# Altium schematic sheet ICWSHLP2_Adaptor_2x2_Power_PreReg.SchDoc(ICWSHLP2_Adaptor_2x2_Power_PreReg)
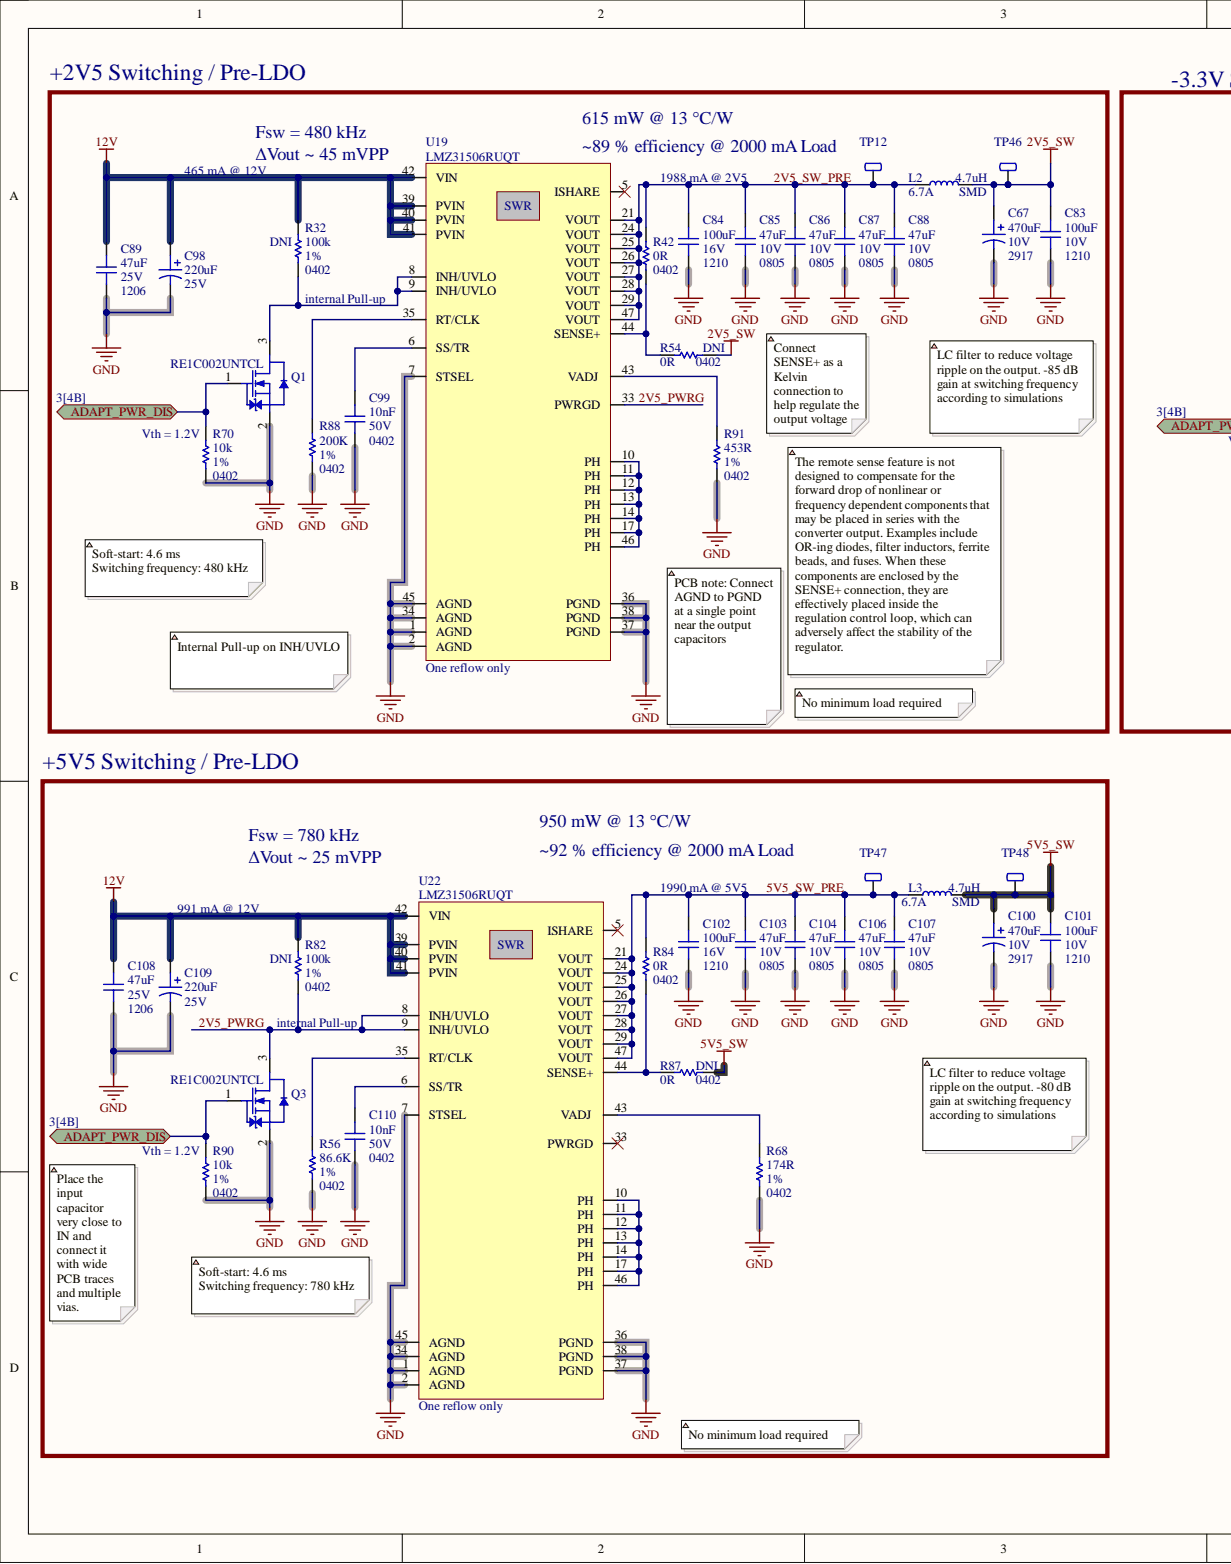
[diagram p0: ICWSHLP2_Adaptor_2x2_Power_PreReg.SchDoc, left]
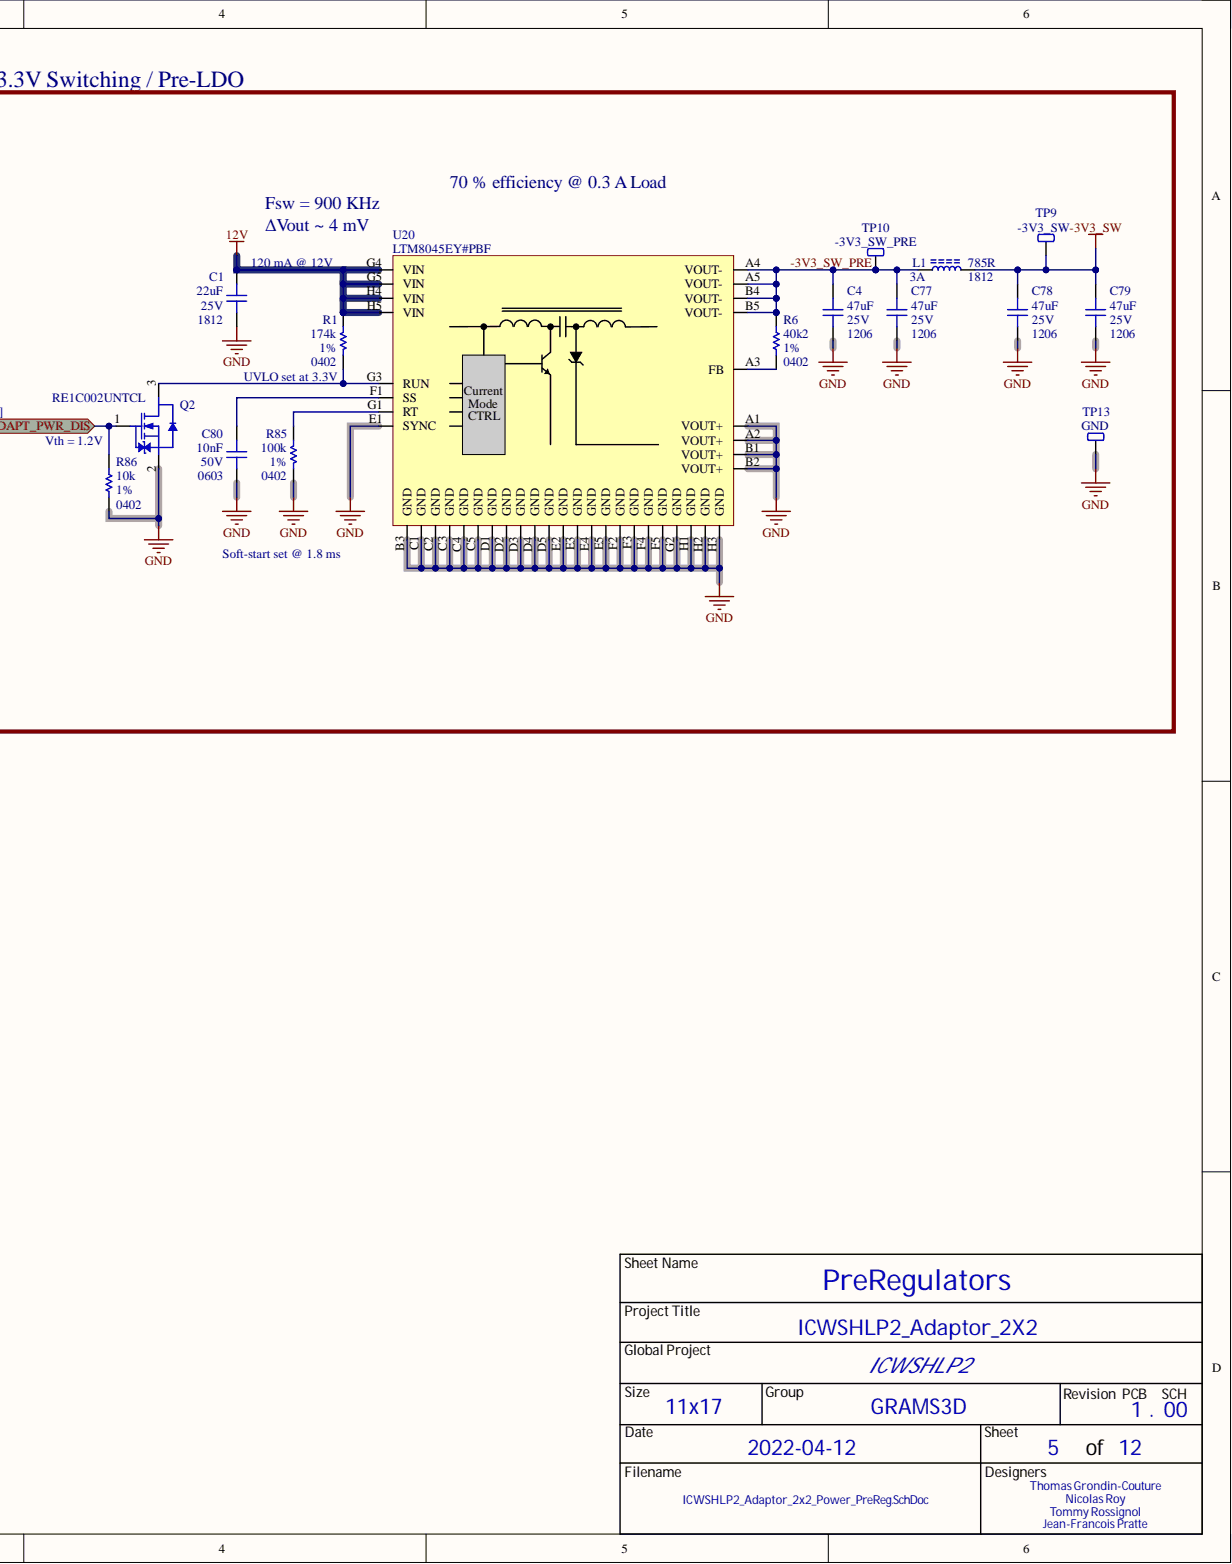
[diagram p1: ICWSHLP2_Adaptor_2x2_Power_PreReg.SchDoc, right]

## Parts
C1  value 22uF  Package: 1812  Voltage Rating: 25V  [CAP_CER_1812]  (hidden comment: C4532X7R1E226M250KC)
C4  value 47uF  Package: 1206  Voltage Rating: 25V  [CAP_CER_1206]  (hidden comment: C3216X5R1E476M160AC)
C67  value 470uF  Assembly Type: SMD  Package: 2917  Voltage Rating: 10V  [CAP_TAN_TR3E477M010C0200]  (hidden comment: TR3E477M010C0200)
C77  value 47uF  Package: 1206  Voltage Rating: 25V  [CAP_CER_1206]  (hidden comment: C3216X5R1E476M160AC)
C78  value 47uF  Package: 1206  Voltage Rating: 25V  [CAP_CER_1206]  (hidden comment: C3216X5R1E476M160AC)
C79  value 47uF  Package: 1206  Voltage Rating: 25V  [CAP_CER_1206]  (hidden comment: C3216X5R1E476M160AC)
C80  value 10nF  Package: 0603  Voltage Rating: 50V  [CAP_CER_0603]  (hidden comment: GRM1885C1H103JA01D)
C83  value 100uF  Package: 1210  Voltage Rating: 10V  [CAP_CER_1210]  (hidden comment: CL32A107MPVNNNE)
C84  value 100uF  Package: 1210  Voltage Rating: 16V  [CAP_CER_1210]  (hidden comment: C1210C107M4PAC7800)
C85  value 47uF  Package: 0805  Voltage Rating: 10V  [CAP_CER_0805]  (hidden comment: GRM21BR61A476ME15L)
C86  value 47uF  Package: 0805  Voltage Rating: 10V  [CAP_CER_0805]  (hidden comment: GRM21BR61A476ME15L)
C87  value 47uF  Package: 0805  Voltage Rating: 10V  [CAP_CER_0805]  (hidden comment: GRM21BR61A476ME15L)
C88  value 47uF  Package: 0805  Voltage Rating: 10V  [CAP_CER_0805]  (hidden comment: GRM21BR61A476ME15L)
C89  value 47uF  Package: 1206  Voltage Rating: 25V  [CAP_CER_1206]  (hidden comment: C3216X5R1E476M160AC)
C98  value 220uF  Voltage Rating: 25V  [CAP_POLY_870025575007]  (hidden comment: 870025575007)
C99  value 10nF  Package: 0402  Voltage Rating: 50V  [CAP_CER_0402]  (hidden comment: C0402C103M5RACTU)
C100  value 470uF  Assembly Type: SMD  Package: 2917  Voltage Rating: 10V  [CAP_TAN_TR3E477M010C0200]  (hidden comment: TR3E477M010C0200)
C101  value 100uF  Package: 1210  Voltage Rating: 10V  [CAP_CER_1210]  (hidden comment: CL32A107MPVNNNE)
C102  value 100uF  Package: 1210  Voltage Rating: 16V  [CAP_CER_1210]  (hidden comment: C1210C107M4PAC7800)
C103  value 47uF  Package: 0805  Voltage Rating: 10V  [CAP_CER_0805]  (hidden comment: GRM21BR61A476ME15L)
C104  value 47uF  Package: 0805  Voltage Rating: 10V  [CAP_CER_0805]  (hidden comment: GRM21BR61A476ME15L)
C106  value 47uF  Package: 0805  Voltage Rating: 10V  [CAP_CER_0805]  (hidden comment: GRM21BR61A476ME15L)
C107  value 47uF  Package: 0805  Voltage Rating: 10V  [CAP_CER_0805]  (hidden comment: GRM21BR61A476ME15L)
C108  value 47uF  Package: 1206  Voltage Rating: 25V  [CAP_CER_1206]  (hidden comment: C3216X5R1E476M160AC)
C109  value 220uF  Voltage Rating: 25V  [CAP_POLY_870025575007]  (hidden comment: 870025575007)
C110  value 10nF  Package: 0402  Voltage Rating: 50V  [CAP_CER_0402]  (hidden comment: C0402C103M5RACTU)
L1  value 785R  Current Rating: 3A  Package: 1812  [FER_1812]  (hidden comment: 742792515)
L2  value 4.7uH  Assembly Type: SMD  Current Rating: 6.7A  [IND_PG0871.472NLT]  (hidden comment: PG0871.472NLT)
L3  value 4.7uH  Assembly Type: SMD  Current Rating: 6.7A  [IND_PG0871.472NLT]  (hidden comment: PG0871.472NLT)
Q1  RE1C002UNTCL  [TRX_NMOS_RE1C002UNTCL]
Q2  RE1C002UNTCL  [TRX_NMOS_RE1C002UNTCL]
Q3  RE1C002UNTCL  [TRX_NMOS_RE1C002UNTCL]
R1  value 174k  Package: 0402  Tolerance: 1%  [RES_0402]  (hidden comment: RC0402FR-07174KL)
R6  value 40k2  Package: 0402  Tolerance: 1%  [RES_0402]  (hidden comment: RC0402FR-0740K2L)
R32  value 100k  Assembly Note: DNI  Package: 0402  Tolerance: 1%  [RES_0402]  (hidden comment: RC0402FR-07100KL)
R42  value 0R  Package: 0402  [RES_0402]  (hidden comment: RC0402JR-070RL)
R54  value 0R  Assembly Note: DNI  Package: 0402  [RES_0402]  (hidden comment: RC0402JR-070RL)
R56  value 86.6K  Package: 0402  Tolerance: 1%  [RES_0402]  (hidden comment: RC0402FR-0786K6L)
R68  value 174R  Package: 0402  Tolerance: 1%  [RES_0402]  (hidden comment: RC0402FR-07174RL)
R70  value 10k  Package: 0402  Tolerance: 1%  [RES_0402]  (hidden comment: RC0402FR-0710KP)
R82  value 100k  Assembly Note: DNI  Package: 0402  Tolerance: 1%  [RES_0402]  (hidden comment: RC0402FR-07100KL)
R84  value 0R  Package: 0402  [RES_0402]  (hidden comment: RC0402JR-070RL)
R85  value 100k  Package: 0402  Tolerance: 1%  [RES_0402]  (hidden comment: RC0402FR-07100KL)
R86  value 10k  Package: 0402  Tolerance: 1%  [RES_0402]  (hidden comment: RC0402FR-0710KP)
R87  value 0R  Assembly Note: DNI  Package: 0402  [RES_0402]  (hidden comment: RC0402JR-070RL)
R88  value 200K  Package: 0402  Tolerance: 1%  [RES_0402]  (hidden comment: RC0402FR-07200KL)
R90  value 10k  Package: 0402  Tolerance: 1%  [RES_0402]  (hidden comment: RC0402FR-0710KP)
R91  value 453R  Package: 0402  Tolerance: 1%  [RES_0402]  (hidden comment: ERJ-2RKF4530X)
TP9  -3V3_SW  [TPO_SMD_RCU-0C]
TP10  -3V3_SW_PRE  [TPO_SMD_RCU-0C]
TP12  2V5_SW_PRE  [TPO_SMD_RCU-0C]
TP13  GND  [TPO_SMD_RCU-0C]
TP46  2V5_SW  [TPO_SMD_RCU-0C]
TP47  5V5_SW_PRE  [TPO_SMD_RCU-0C]
TP48  5V5_SW  [TPO_SMD_RCU-0C]
U19  LMZ31506RUQT  Assembly Note: One reflow only  [REG_SWR_LMZ31506RUQT]
U20  LTM8045EY#PBF  [REG_SWR_LTM8045EY#PBF]
U22  LMZ31506RUQT  Assembly Note: One reflow only  [REG_SWR_LMZ31506RUQT]

## Ports
ADAPT_PWR_DIS

## Nets
2V5_SW: C67.1 C83.2 L2.1 R54.1 TP46.1
5V5_SW: C100.1 C101.2 L3.1 R87.1 TP48.1
12V: C1.2 C89.2 C98.1 C108.2 C109.1 R1.2 R32.2 R82.2 U19.39(PVIN) U19.40(PVIN) U19.41(PVIN) U19.42(VIN) U20.G4(VIN) U20.G5(VIN) U20.H4(VIN) U20.H5(VIN) U22.39(PVIN) U22.40(PVIN) U22.41(PVIN) U22.42(VIN)
-3V3_SW: C78.2 C79.2 L1.2 TP9.1
GND: C1.1 C4.1 C67.2 C77.1 C78.1 C79.1 C80.1 C83.1 C84.1 C85.1 C86.1 C87.1 C88.1 C89.1 C98.2 C99.1 C100.2 C101.1 C102.1 C103.1 C104.1 C106.1 C107.1 C108.1 C109.2 C110.1 Q1.2 Q2.2 Q3.2 R56.1 R68.1 R70.1 R85.1 R86.1 R88.1 R90.1 R91.1 TP13.1 U19.1(AGND) U19.2(AGND) U19.7(STSEL) U19.34(AGND) U19.36(PGND) U19.37(PGND) U19.38(PGND) U19.45(AGND) U20.A1(VOUT+) U20.A2(VOUT+) U20.B1(VOUT+) U20.B2(VOUT+) U20.B3(GND) U20.C1(GND) U20.C2(GND) U20.C3(GND) U20.C4(GND) U20.C5(GND) U20.D1(GND) U20.D2(GND) U20.D3(GND) U20.D4(GND) U20.D5(GND) U20.E1(SYNC) U20.E2(GND) U20.E3(GND) U20.E4(GND) U20.E5(GND) U20.F2(GND) U20.F3(GND) U20.F4(GND) U20.F5(GND) U20.G2(GND) U20.H1(GND) U20.H2(GND) U20.H3(GND) U22.1(AGND) U22.2(AGND) U22.7(STSEL) U22.34(AGND) U22.36(PGND) U22.37(PGND) U22.38(PGND) U22.45(AGND)
2V5_PWRG: Q3.3 R82.1 U19.33(PWRGD) U22.8(INH/UVLO) U22.9(INH/UVLO)
2V5_SW_PRE: C84.2 C85.2 C86.2 C87.2 C88.2 L2.2 R42.2 TP12.1 U19.21(VOUT) U19.24(VOUT) U19.25(VOUT) U19.26(VOUT) U19.27(VOUT) U19.28(VOUT) U19.29(VOUT) U19.47(VOUT)
5V5_SW_PRE: C102.2 C103.2 C104.2 C106.2 C107.2 L3.2 R84.2 TP47.1 U22.21(VOUT) U22.24(VOUT) U22.25(VOUT) U22.26(VOUT) U22.27(VOUT) U22.28(VOUT) U22.29(VOUT) U22.47(VOUT)
-3V3_SW_PRE: C4.2 C77.2 L1.1 R6.2 TP10.1 U20.A4(VOUT-) U20.A5(VOUT-) U20.B4(VOUT-) U20.B5(VOUT-)
ADAPT_PWR_DIS: Q1.1 Q2.1 Q3.1 R70.2 R86.2 R90.2 ; port ADAPT_PWR_DIS
NetC80_2: C80.2 U20.F1(SS)
NetC99_2: C99.2 U19.6(SS/TR)
NetC110_2: C110.2 U22.6(SS/TR)
NetQ1_3: Q1.3 R32.1 U19.8(INH/UVLO) U19.9(INH/UVLO)
NetQ2_3: Q2.3 R1.1 U20.G3(RUN)
NetR6_1: R6.1 U20.A3(FB)
NetR42_1: R42.1 R54.2 U19.44(SENSE+)
NetR56_2: R56.2 U22.35(RT/CLK)
NetR68_2: R68.2 U22.43(VADJ)
NetR84_1: R84.1 R87.2 U22.44(SENSE+)
NetR85_2: R85.2 U20.G1(RT)
NetR88_2: R88.2 U19.35(RT/CLK)
NetR91_2: R91.2 U19.43(VADJ)
NetU19_10: U19.10(PH) U19.11(PH) U19.12(PH) U19.13(PH) U19.14(PH) U19.17(PH) U19.46(PH)
NetU22_10: U22.10(PH) U22.11(PH) U22.12(PH) U22.13(PH) U22.14(PH) U22.17(PH) U22.46(PH)

Not connected: U19 5(ISHARE); U22 5(ISHARE) 33(PWRGD)

## Library data of the parts
C1 = CAP_CER_C4532X7R1E226M250KC: CAP - CER 22uF ±20% 25V X7R - 1812; Manufacturer #1: TDK Corporation; Cryo: UNKNOWN; GRAMS Lib Spec: 2.8; Note: DC bias = 21.5uF@5V, 17.6uF@10V; Polarized: No; Assembly Type: SMD; Component Kind: Standard; HelpURL: https://product.tdk.com/info/en/documents/chara_sheet/C4532X7R1E226M250KC.pdf; Library Name: GRAMS_MASTER_LIB.IntLib; Magnetic Material: Unknown; Part Number #1: C4532X7R1E226M250KC; Supplier 1: Digi-Key; Supplier Part Number 1: 445-1607-1-ND; Temperature: -55°C ~ 125°C; Tolerance: 20%; CreatedBy/Date: Keven Deslandes - 2018/12/18; VerifiedBy: Caroline Paulin - 24 janvier 2019
C4 C77-C79 = CAP_CER_C3216X5R1E476M160AC: CAP - CER 47uF 20% 25V X5R - 1206; Manufacturer #1: TDK Corporation; Cryo: UNKNOWN; GRAMS Lib Spec: 2.9; Polarized: NO; Solder Paste Type: TYPE 3; Assembly Type: SMD; Component Kind: Standard; HelpURL: https://product.tdk.com/info/en/catalog/datasheets/mlcc_commercial_general_en.pdf; Library Name: GRAMS_MASTER_LIB.IntLib; Magnetic Material: UNKNOWN; Part Number #1: C3216X5R1E476M160AC; Stencil Thickness (Recommended): UNKNOWN; Supplier 1: Digi-Key; Supplier Part Number 1: 445-8047-1-ND; Temperature: -55°C ~ 85°C; Tolerance: 20%; CreatedBy/Date: Thomas Grondin-Couture- 2020/10/09; VerifiedBy: Nicolas Roy/2020-11-18
C67 C100 = CAP_TAN_TR3E477M010C0200: CAP TANT 470UF 20% 10V 2917; Manufacturer #1: Vishay Sprague; Cryo: UNKNOWN; GRAMS Lib Spec: 2.8; Polarized: Yes; Component Kind: Standard; Current Rating: -; HelpURL: https://www.vishay.com/docs/40080/tr3.pdf; Magnetic Material: UNKNOWN; Manufacturer #2: -; Note: -; Part Number #1: TR3E477M010C0200; Part Number #2: -; Power Max: -; Solder Paste Type: UNKNOWN; Stencil Thickness (Recommended): UNKNOWN; Supplier 1: Digi-Key; Supplier Part Number 1: 718-1479-1-ND; Temperature: -55°C ~ 125°C; Tolerance: 20%; CreatedBy/Date: Thomas G-C - 2021-06--1; Library Name: Lib_temp_Thomas_GC.SchLib
C80 = CAP_CER_GRM1885C1H103JA01D: CAP - CER 10nF 5% 50V C0G/NP0 - 0603; Manufacturer #1: Murata Electronics North America; Cryo: UNKNOWN; GRAMS Lib Spec: 2.8; Polarized: NO; Assembly Type: SMD; Component Kind: Standard; HelpURL: https://www.murata.com/~/media/webrenewal/support/library/catalog/products/capacitor/mlcc/c02e.ashx?la=en-us; Library Name: GRAMS_MASTER_LIB.IntLib; Magnetic Material: Unknown; Part Number #1: GRM1885C1H103JA01D; Supplier 1: Digi-Key; Supplier Part Number 1: 490-9666-1-ND; Temperature: -55°C ~ 125°C; Tolerance: 5%; CreatedBy/Date: Nicolas Roy 2018/12/19; VerifiedBy: Nicolas V. (2019-01-22)
C83 C101 = CAP_CER_CL32A107MPVNNNE: CAP - CER 100UF 20% 10V X5R - 1210; Manufacturer #1: Samsung Electro-Mechanics; Cryo: UNKNOWN; GRAMS Lib Spec: 2.8; Polarized: NO; Assembly Type: SMD; Component Kind: Standard; HelpURL: http://www.samsungsem.com/kr/support/product-search/mlcc/__icsFiles/afieldfile/2018/06/21/CL32A107MPVNNNE.pdf; Library Name: GRAMS_MASTER_LIB_SCH.SchLib; Magnetic Material: UNKNOWN; Manufacturer #2: Murata Electronics North America; Part Number #1: CL32A107MPVNNNE; Part Number #2: GRM32ER61A107ME20L; Solder Paste Type: UNKNOWN; Stencil Thickness (Recommended): UNKNOWN; Supplier 1: Digi-Key; Supplier Part Number 1: 1276-3364-1-ND; Temperature: -55°C ~ 85°C; Tolerance: 20%; CreatedBy/Date: Arnaud Samson - 09/07/18; VerifiedBy: Nicolas V. (2019-01-22)
C84 C102 = CAP_CER_C1210C107M4PAC7800: CAP - CER 100UF 20% 16V X5R - 1210; Manufacturer #1: KEMET; Cryo: UNKNOWN; GRAMS Lib Spec: 2.8; Polarized: No; Assembly Type: SMD; Component Kind: Standard; HelpURL: https://content.kemet.com/datasheets/KEM_C1006_X5R_SMD.pdf; Library Name: GRAMS_MASTER_LIB_SCH.SchLib; Magnetic Material: UNKNOWN; Part Number #1: C1210C107M4PAC7800; Solder Paste Type: UNKNOWN; Stencil Thickness (Recommended): UNKNOWN; Supplier 1: Digi-Key; Supplier Part Number 1: 399-11270-1-ND; Temperature: -55°C ~ 85°C; Tolerance: 20%; CreatedBy/Date: Justin Breton - 2020-01-16; VerifiedBy: C.Paulin - 28 janvier 2020
C85-C88 C103 C104 C106 C107 = CAP_CER_GRM21BR61A476ME15L: CAP - CER 47uF 20% 10V X5R - 0805; Manufacturer #1: Murata; Cryo: UNKNOWN; GRAMS Lib Spec: 2.8; Polarized: No; Assembly Type: SMD; Component Kind: Standard; HelpURL: http://search.murata.co.jp/Ceramy/image/img/A01X/G101/ENG/GRM21BR61A476ME15-01.pdf; Library Name: GRAMS_MASTER_LIB_SCH.SchLib; Magnetic Material: Unknown; Part Number #1: GRM21BR61A476ME15L; Supplier 1: Digi-Key; Supplier Part Number 1: 490-9961-1-ND; Temperature: -55°C ~ 85°C; Tolerance: 20%; CreatedBy/Date: Joel Lemay 2018-06-19; VerifiedBy: Caroline Paulin - 7 sept 2018
C89 C108 = CAP_CER_C3216X5R1E476M160AC: CAP - CER 47uF 20% 25V X5R - 1206; Manufacturer #1: TDK Corporation; Cryo: UNKNOWN; GRAMS Lib Spec: 2.9; Polarized: NO; Assembly Type: SMD; Component Kind: Standard; HelpURL: https://product.tdk.com/info/en/catalog/datasheets/mlcc_commercial_general_en.pdf; Library Name: GRAMS_MASTER_LIB_SCH.SchLib; Magnetic Material: UNKNOWN; Part Number #1: C3216X5R1E476M160AC; Solder Paste Type: UNKNOWN; Stencil Thickness (Recommended): UNKNOWN; Supplier 1: Digi-Key; Supplier Part Number 1: 445-8047-1-ND; Temperature: -55°C ~ 85°C; Tolerance: 20%; CreatedBy/Date: Thomas Grondin-Couture- 2020/10/09; VerifiedBy: Nicolas Roy/2020-11-18
C98 C109 = CAP_POLY_870025575007: CAP - ALUM POLY 220UF 20% 25V - 2-TH; Manufacturer #1: Wurth Electronics Inc.; Cryo: UNKNOWN; Current Rating: 4.9A RMS (100kHz); GRAMS Lib Spec: 2.8; Polarized: Yes; Assembly Type: TH; Component Kind: Standard; HelpURL: https://katalog.we-online.de/pbs/datasheet/870025575007.pdf; Library Name: GRAMS_MASTER_LIB.IntLib; Magnetic Material: Unknown; Package: 2-TH; Part Number #1: 870025575007; Supplier 1: Digi-Key; Supplier Part Number 1: 732-6329-1-ND; Temperature: -55°C ~ 105°C; Tolerance: 20%; CreatedBy/Date: Nicolas Roy - 17/12/18; VerifiedBy: Nicolas V. (2019-01-23)
C99 C110 = CAP_CER_C0402C103M5RACTU: CAP - CER 10nF 50V X7R 20% - 0402; Manufacturer #1: KEMET; Cryo: UNKNOWN; GRAMS Lib Spec: 2.8; Polarized: No; Assembly Type: SMD; Component Kind: Standard; HelpURL: https://api.kemet.com/component-edge/download/datasheet/C0402C103M5RACTU.pdf; Library Name: GRAMS_MASTER_LIB_SCH.SchLib; Magnetic Material: Unknown; Part Number #1: C0402C103M5RACTU; Supplier 1: Digi-Key; Supplier Part Number 1: 399-7760-1-ND; Temperature: -55°C ~ 125°C; Tolerance: 20%; CreatedBy/Date: Keven Deslandes - 2018/11/09; VerifiedBy: Nicolas Roy - 2018/12/11
L1 = FER_742792515: FER - FERRITE BEAD 785 OHM (100 MHz)  3A - 1812; Manufacturer #1: Wurth Electronics Inc.; Cryo: UNKNOWN; GRAMS Lib Spec: 2.8; Polarized: No; Tolerance: 25%; Assembly Type: SMD; Component Kind: Standard; HelpURL: https://katalog.we-online.de/pbs/datasheet/742792515.pdf; Library Name: GRAMS_MASTER_LIB.IntLib; Magnetic Material: Unknown; Part Number #1: 742792515; Supplier 1: Digi-Key; Supplier Part Number 1: 732-1642-1-ND; Temperature: -55°C ~ 125°C; CreatedBy/Date: Nicolas Roy 2018-10-17; VerifiedBy: Caroline Paulin - 23 janv 2019
L2 L3 = IND_PG0871.472NLT: FIXED IND 4.7UH 6.7A 17 MOHM SMD; Manufacturer #1: Pulse Electronics Power; Part Number #1: 732-2525-1-ND; Cryo: UNKNOWN; GRAMS Lib Spec: 2.8; Polarized: Yes; Tolerance: 20%; Component Kind: Standard; HelpURL: https://www.digikey.ca/en/products/detail/pulse-electronics-power/PG0871-472NLT/2266593; Magnetic Material: Unknown; Package: SMD; Supplier 1: Digi-Key; Supplier Part Number 1: 553-2169-1-ND; Temperature: -40°C ~ 130°C; CreatedBy/Date: Thomas G-C - 2021-06-01; Library Name: Lib_temp_Thomas_GC.SchLib
Q1-Q3 = TRX_NMOS_RE1C002UNTCL: TRX - NMOS 20V 200mA- SC-89; Manufacturer #1: Rohm Semiconductor; Cryo: UNKNOWN; Current Rating: 200mA; GRAMS Lib Spec: 2.8; Polarized: Yes; Power Max: 150mW; Voltage rating: 20V (Vds max), 1.0V (Vgs @ 1mA); Assembly Type: SMD; Component Kind: Standard; HelpURL: https://www.rohm.com/datasheet/RE1C002UN/re1c002untcl-e; Library Name: GRAMS_MASTER_LIB.IntLib; Magnetic Material: Unknown; Package: SC-89; Part Number #1: RE1C002UNTCL; Supplier 1: Digi-Key; Supplier Part Number 1: RE1C002UNTCLCT-ND; Temperature: -55°C ~ 150°C (Tj); CreatedBy/Date: Nicolas Roy / 27 nov. 2018; VerifiedBy: Caroline Paulin - 28 janv 2019
R1 = RES_RC0402FR-07174KL: RES - 174K 1% 1/16W - 0402; Manufacturer #1: Yageo; Cryo: UNKNOWN; GRAMS Lib Spec: 2.8; Polarized: No; Voltage Rating: 50V; Assembly Type: SMD; Component Kind: Standard; HelpURL: http://www.yageo.com/documents/recent/PYu-RC_Group_51_RoHS_L_9.pdf; Library Name: GRAMS_MASTER_LIB.IntLib; Magnetic Material: Unknown; Part Number #1: RC0402FR-07174KL; Power Max: 1/16W; Supplier 1: Digi-Key; Supplier Part Number 1: YAG3008CT-ND; Temperature: -55°C ~ 155°C; CreatedBy/Date: Keven Deslandes 2018-09-24; VerifiedBy: Caroline Paulin - 18/12/2018
R6 = RES_RC0402FR-0740K2L: RES - 40.2K 1% 1/16W - 0402; Manufacturer #1: Yageo; Cryo: UNKNOWN; GRAMS Lib Spec: 2.8; Polarized: No; Solder Paste Type: TYPE 3; Voltage Rating: 50V; Assembly Type: SMD; Component Kind: Standard; Current Rating: -; HelpURL: http://www.yageo.com/documents/recent/PYu-RC_Group_51_RoHS_L_10.pdf; Library Name: GRAMS_MASTER_LIB_SCH.SchLib; Magnetic Material: UNKNOWN; Manufacturer #2: -; Note: -; Part Number #1: RC0402FR-0740K2L; Part Number #2: -; Power Max: 1/16W; Stencil Thickness (Recommended): UNKNOWN; Supplier 1: Digi-Key; Supplier Part Number 1: 311-40.2KLRCT-ND; Temperature: -55°C ~ 155°C; CreatedBy/Date: Raphael Bouchard - 2019-08-09; VerifiedBy: C.Paulin - 15/08/2019
R32 R82 R85 = RES_RC0402FR-07100KL: RES - 100K 1% 1/16W - 0402; Manufacturer #1: Yageo; Cryo: UNKNOWN; GRAMS Lib Spec: 2.8; Polarized: No; Voltage Rating: 50V; Assembly Type: SMD; Component Kind: Standard; HelpURL: http://www.yageo.com/documents/recent/PYu-RC_Group_51_RoHS_L_9.pdf; Library Name: GRAMS_MASTER_LIB.IntLib; Magnetic Material: Unknown; Part Number #1: RC0402FR-07100KL; Power Max: 1/16W; Supplier 1: Digi-Key; Supplier Part Number 1: 311-100KLRCT-ND; Temperature: -55°C ~ 155°C; CreatedBy/Date: Keven Deslandes 2018-10-19; VerifiedBy: Caroline Paulin - 18/12/2018
R42 R54 R84 R87 = RES_RC0402JR-070RL: RES - 0 OHM JUMPER 1/16W - 0402; Manufacturer #1: Yageo; Cryo: UNKNOWN; GRAMS Lib Spec: 2.8; Magnetic Material: YES; Polarized: NO; Voltage Rating: 50V; Assembly Type: SMD; Component Kind: Standard; HelpURL: http://www.yageo.com/documents/recent/PYu-RC_Group_51_RoHS_L_9.pdf; Library Name: GRAMS_MASTER_LIB_SCH.SchLib; Part Number #1: RC0402JR-070RL; Power Max: 1/16W; Solder Paste Type: UNKNOWN; Stencil Thickness (Recommended): UNKNOWN; Supplier 1: Digi-Key; Supplier Part Number 1: 311-0.0JRCT-ND; Temperature: -55°C ~ 155°C; Tolerance: N/A; CreatedBy/Date: Jonathan Bouchard 2018-07-05; VerifiedBy: Arnaud Samson 16-07-18
R56 = RES_RC0402FR-0786K6L: 86.6 kOhms ±1% 0.063W, 1/16W Chip Resistor 0402 (1005 Metric) Moisture Resistant Thick FilmResistant Thick Film; Manufacturer #1: Yageo; Part Number #1: RC0402FR-07200KL; Cryo: UNKNOWN; GRAMS Lib Spec: 2.8; Polarized: No; Assembly Type: SMD; Component Kind: Standard; HelpURL: https://www.yageo.com/upload/media/product/productsearch/datasheet/rchip/PYu-RC_Group_51_RoHS_L_11.pdf; Magnetic Material: Unknown; Power Max: 1/16W; Supplier 1: Digi-Key; Supplier Part Number 1: YAG3249CT-ND; Temperature: -55°C ~ 155°C; Voltage Rating: -; CreatedBy/Date: Thomas G-C 2021-06-02; Library Name: Lib_temp_Thomas_GC.SchLib
R68 = RES_RC0402FR-07174RL: RES - 174R 1% 1/16W - 0402; Manufacturer #1: Yageo; Cryo: UNKNOWN; GRAMS Lib Spec: 2.8; Polarized: No; Voltage Rating: 50V; Assembly Type: SMD; Component Kind: Standard; HelpURL: http://www.yageo.com/documents/recent/PYu-RC_Group_51_RoHS_L_9.pdf; Library Name: GRAMS_MASTER_LIB_SCH.SchLib; Magnetic Material: UNKNOWN; Part Number #1: RC0402FR-07174RL; Power Max: 1/16W; Solder Paste Type: UNKNOWN; Stencil Thickness (Recommended): UNKNOWN; Supplier 1: Digi-Key; Supplier Part Number 1: YAG3009CT-ND; Temperature: -55°C ~ 155°C; CreatedBy/Date: Keven Deslandes - 2018-11-15; VerifiedBy: Caroline Paulin - 18/12/2018
R70 R86 R90 = RES_RC0402FR-0710KP: RES - 10K OHM 1% 1/16W - 0402; Manufacturer #1: Yageo; Cryo: UNKNOWN; GRAMS Lib Spec: 2.8; Magnetic Material: YES; Polarized: NO; Voltage Rating: 50V; Assembly Type: SMD; Component Kind: Standard; HelpURL: http://www.yageo.com/documents/recent/PYu-RC_Group_51_RoHS_L_9.pdf; Library Name: GRAMS_MASTER_LIB.IntLib; Part Number #1: RC0402FR-0710KP; Power Max: 1/16W; Supplier 1: Digi-Key; Supplier Part Number 1: YAG1249CT-ND; Temperature: -55°C ~ 155°C; CreatedBy/Date: Jonathan Bouchard 2018-07-05; VerifiedBy: Arnaud Samson 16-07-18
R88 = RES_RC0402FR-07200KL: 200 kOhms ±1% 0.063W, 1/16W Chip Resistor 0402 (1005 Metric) Moisture Resistant Thick Film; Manufacturer #1: Yageo; Cryo: UNKNOWN; GRAMS Lib Spec: 2.8; Polarized: No; Assembly Type: SMD; Component Kind: Standard; HelpURL: https://www.yageo.com/upload/media/product/productsearch/datasheet/rchip/PYu-RC_Group_51_RoHS_L_11.pdf; Magnetic Material: Unknown; Part Number #1: RC0402FR-07200KL; Power Max: 1/16W; Supplier 1: Digi-Key; Supplier Part Number 1: 311-200KLRCT-ND; Temperature: -55°C ~ 155°C; Voltage Rating: -; CreatedBy/Date: Thomas G-C 2021-05-27; Library Name: Lib_temp_Thomas_GC.SchLib
R91 = RES_ERJ-2RKF4530X: RES - 453 OHM 1% 1/10W - 0402; Manufacturer #1: Panasonic Electronic Components; Cryo: UNKNOWN; GRAMS Lib Spec: 2.8; Polarized: NO; Power Max: 0.1W; Assembly Type: SMD; Component Kind: Standard; HelpURL: https://industrial.panasonic.com/ww/products/resistors/chip-resistors/general-purpose-chip-resistors/precision-thick-film-chip-resistors/ERJ2RKF4530X; Library Name: GRAMS_MASTER_LIB_SCH.SchLib; Magnetic Material: UNKNOWN; Part Number #1: ERJ-2RKF4530X; Solder Paste Type: UNKNOWN; Stencil Thickness (Recommended): UNKNOWN; Supplier 1: Digi-Key; Supplier Part Number 1: P453LCT-ND; Temperature: -55°C ~ 155°C; CreatedBy/Date: Tommy Rossignol / 2018-11-20; VerifiedBy: Caroline Paulin - 27 fév 2019
TP9 TP10 TP12 TP13 TP46-TP48 = TPO_SMD_RCU-0C: TPO - TEST POINT PROBE PAD - 0603; Manufacturer #1: TE Connectivity AMP Connectors; Part Number #1: RCU-0C; Cryo: UNKNOWN; GRAMS Lib Spec: 2.8; Polarized: No; Value: 1.6 mm x 0.8 mm; Assembly Type: SMD; Component Kind: Standard; HelpURL: http://www.te.com/commerce/DocumentDelivery/DDEController?Action=srchrtrv&DocNm=1773266&DocType=DS&DocLang=English; Library Name: GRAMS_MASTER_LIB.IntLib; Magnetic Material: UNKNOWN; Package: 0603; Supplier 1: Digi-Key; Supplier Part Number 1: A106145CT-ND; CreatedBy/Date: Olivier Bernard / 20/5/2018; VerifiedBy: Caroline Paulin / 6 juillet 2018
U19 U22 = REG_SWR_LMZ31506RUQT: REG - DC DC CONVERTER 0.6-5.5V 6A 33W - 47-BQFN; Manufacturer #1: Texas Instruments; Cryo: UNKNOWN; GRAMS Lib Spec: 2.8; Polarized: Yes; Value: 0.6 ~ 5.5V; Voltage Rating: PVIN : 1.6 ~ 14.5V; Assembly Type: SMD; Component Kind: Standard; Current Rating: 6A; HelpURL: http://www.ti.com/lit/ds/symlink/lmz31506.pdf; Library Name: GRAMS_MASTER_LIB_SCH.SchLib; Magnetic Material: Unknown; Manufacturer #2: -; Note: -; Package: 47-BQFN; Part Number #1: LMZ31506RUQT; Part Number #2: -; Power Max: 33W; Supplier 1: Digi-Key; Supplier Part Number 1: 296-37032-1-ND; Temperature: -40°C ~ 85°C; Tolerance: -; CreatedBy/Date: Raphael Bouchard / 2019-06-07; VerifiedBy: C.Paulin - 14/06/2019
U20 = REG_SWR_LTM8045EY#PBF: REG - DC DC CONVERTER +/-2.5 +/-15V, up to 700 mA - 40-BBGA; Manufacturer #1: Analog Devices Inc.; Cryo: UNKNOWN; GRAMS Lib Spec: 3.2; Polarized: Yes; Solder Paste Type: TYPE 3; Value: +/-2.5 ~ +/-15 V; Voltage Rating: 2.8V to 18V IN; Assembly Type: SMD; Component Kind: Standard; Current Rating: Up to 700 mA; HelpURL: https://www.analog.com/media/en/technical-documentation/data-sheets/8045fc.pdf; Library Name: GRAMS_MASTER_LIB_SCH.SchLib; Magnetic Material: UNKNOWN; Package: 40-BGA; Part Number #1: LTM8045EY#PBF; Stencil Thickness (Recommended): Unknown; Supplier 1: Digi-Key; Supplier Part Number 1: 505-LTM8045EY#PBF-ND; Temperature: -40°C ~ 125°C; CreatedBy/Date: Nicolas Roy/2022-04-26; VerifiedBy: C.Paulin - 26/04/2022
